# Altium schematic sheet TPS612997YBHR_3.6V_lowIQ_1.9A.SchDoc
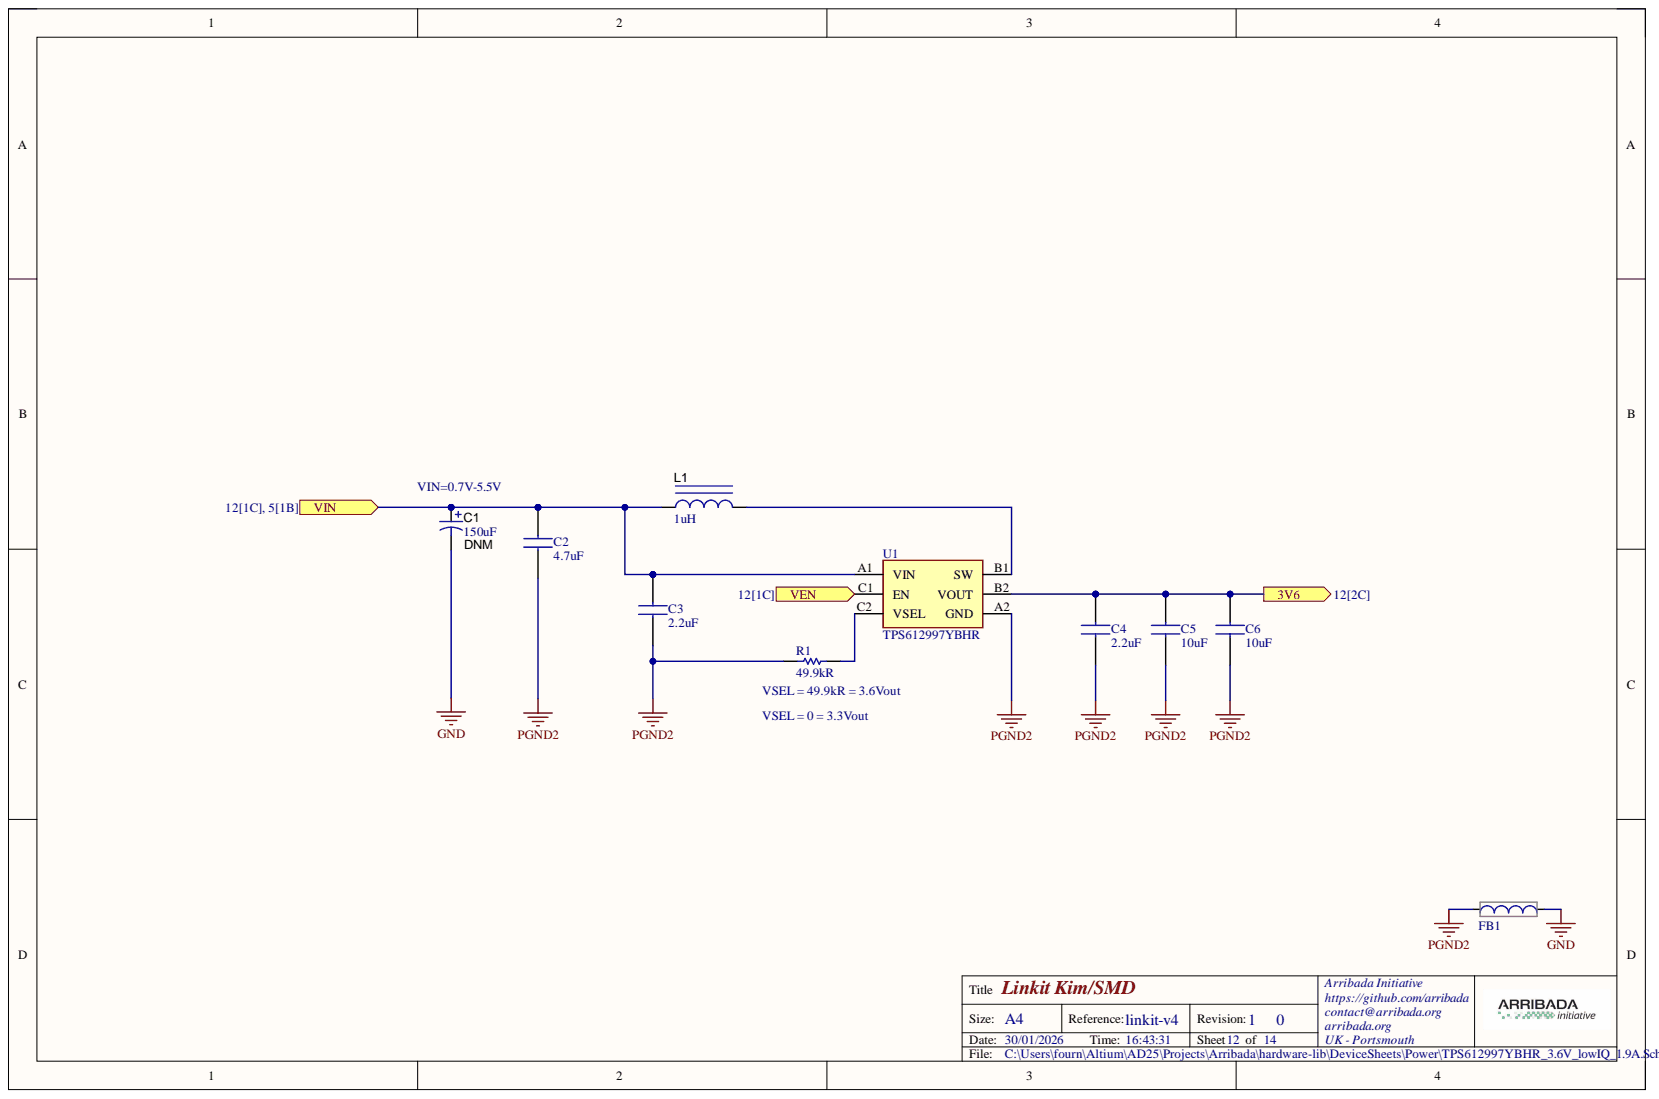
[diagram p0: TPS612997YBHR_3.6V_lowIQ_1.9A.SchDoc]

## Parts
C1  DNM  value 150uF  [7343-31]
C2  value 4.7uF  [CAPC1608X90N]  (hidden comment: GRM188Z71A475ME15D)
C3  value 2.2uF  [CAPC1005X70N]  (hidden comment: GRM155R61A225ME95D)
C4  value 2.2uF  [CAPC1005X70N]  (hidden comment: GRM155R61A225ME95D)
C5  value 10uF  [CAPC1005X55N]  (hidden comment: GRM155R61A106ME11D)
C6  value 10uF  [CAPC1005X55N]  (hidden comment: GRM155R61A106ME11D)
FB1  BLM18AG102SN1D  [BLM18SP221SN1B]  (value not drawn)
L1  value 1uH  [XEL4020]  (hidden comment: XEL4020-102MEB)
R1  value 49.9kR  [RESC1005X40N]  (hidden comment: CRCW040249K9FKED)
U1  TPS612997YBHR  [BGA6C40P2X3_84X123X40]

## Ports
3V6, VEN, VIN

## Nets
GND: C1.2 FB1.2
PGND2: C2.2 C3.1 C4.1 C5.1 C6.1 FB1.1 R1.2 U1.A2(GND)
3V6: C4.2 C5.2 C6.2 U1.B2(VOUT) ; port 3V6
VEN: U1.C1(EN) ; port VEN
VIN: C1.1 C2.1 C3.2 L1.1 U1.A1(VIN) ; port VIN
NetL5_2: L1.2 U1.B1(SW)
NetR50_1: R1.1 U1.C2(VSEL)

## Library data of the parts
C1 = T495D157K010ATE100: Tantalum Capacitors - Solid SMD 10V 150uF 2917 10% ESR=100mOhms; Manufacturer: Kemet; AEC Category: Q200; AEC Qualified: N; Cap_F: 150µF; ESR_ohm: 1d; IRMS_A: 1.225A; Polarized: Y; SizeArea_mm2: 31.39; SizeAreaWB_mm2: 58.59; SizeX_mm: 7.3; SizeY_mm: 4.3; SizeZ_mm: 3.1; TaMax_C: 125°C; TaMin_C: -55°C; U/M: EA; Value1: 0.1 ohm; AEC Grade: N/A; Cap_Case: 7343-31; Component Kind: Standard; ComponentLink1Description: Datasheet link; ComponentLink1URL: http://www.kemet.com/Lists/ProductCatalog/Attachments/261/KEM_T2009_T495.pdf; CompType: Capacitor; LatestRevisionDate: 2017-03-09; LatestRevisionNote: Updated supplier links; Library Name: stm32wl-dev.SchLib; MountType: SMT; PackageReference: 7343-31; PartNumber: T495D157K010ATE100; RoHS: Y; Series: T495; Supplier 1: Digi-Key; Supplier 2: Newark; Supplier 3: Mouser; Supplier Part Number 1: 399-8506-1-ND; Supplier Part Number 2: 40K0920; Supplier Part Number 3: 80-T495D157K010; Technology: TA; Tolerance_%: 10%; Value2: 10V; ValueDisplayed: 150uF; VDC_V: 10V; Publisher: Texas Instruments
C2 = GRM188Z71A475ME15D: Multilayer Ceramic Capacitors MLCC - SMD/SMT 4.7 uF 10 VDC 20% 0603 X7R; Manufacturer: Murata; Manufacturer_Name: Murata Electronics; Case/Package: 0603; Category: Ceramic Capacitors; Component Kind: Standard; Datasheet Link: http://componentsearchengine.com/Datasheets/1/GRM188Z71A475ME15D.pdf; Height: 0.9mm; Library Name: stm32wl-dev.SchLib; Manufacturer Part Number: GRM188Z71A475ME15D; Manufacturer_Part_Number: GRM188Z71A475ME15D; Mouser Part Number: 81-GRM188Z71A475ME5D; Mouser Price/Stock: https://www.mouser.co.uk/ProductDetail/Murata-Electronics/GRM188Z71A475ME15D?qs=Ey7%2FXF42M3f7ZZnF1u%2FoMQ%3D%3D; Pricing 1: 1=0,2, 10=0,139, 50=0,0928, 100=0,0789, 500=0,0557, 1000=0,04873 (USD); RoHS: Yes; Stock 1: 6936; Supplier 1: Digi-Key
C3 C4 = GRM155R61A225ME95D: Multilayer Ceramic Capacitors MLCC - SMD/SMT 2.2 uF 10 VDC 20% 0402 X5R; Manufacturer_Name: Murata Electronics; Arrow Part Number: GRM155R61A225ME95D; Arrow Price/Stock: https://www.arrow.com/en/products/grm155r61a225me95d/murata-manufacturing; Component Kind: Standard; Datasheet Link: http://www.murata.com/~/media/webrenewal/support/library/catalog/products/capacitor/mlcc/c02e.pdf; Height: 0.7mm; Library Name: stm32wl-dev.SchLib; Manufacturer_Part_Number: GRM155R61A225ME95D; Mouser Part Number: 81-GRM155R61A225ME5D; Mouser Price/Stock: https://www.mouser.co.uk/ProductDetail/Murata-Electronics/GRM155R61A225ME95D?qs=eeBpzGFlv%252B9Q12VVb4vywQ%3D%3D
C5 C6 = GRM155R61A106ME11D: Multilayer Ceramic Capacitors MLCC - SMD/SMT 10 uF 10 VDC 20% 0402 X5R; Manufacturer_Name: Murata Electronics; Arrow Part Number: GRM155R61A106ME11D; Arrow Price/Stock: https://www.arrow.com/en/products/grm155r61a106me11d/murata-manufacturing?region=nac; Component Kind: Standard; Datasheet Link: http://www.murata.com/~/media/webrenewal/support/library/catalog/products/capacitor/mlcc/c02e.pdf; Height: 0.55mm; Library Name: stm32wl-dev.SchLib; Manufacturer_Part_Number: GRM155R61A106ME11D; Mouser Part Number: 81-GRM155R61A106ME1D; Mouser Price/Stock: https://www.mouser.co.uk/ProductDetail/Murata-Electronics/GRM155R61A106ME11D?qs=xZ%2FP%252Ba9zWqZMJSi57Q8OyA%3D%3D
FB1 = BLM18AG102SN1D: Ferrite Bead - 1000 OHM 25%; Manufacturer_Name: Murata Electronics; Arrow Part Number: BLM18AG102SN1D; Arrow Price/Stock: https://www.arrow.com/en/products/blm18ag102sn1d/murata-manufacturing?utm_currency=USD&region=nac; Component Kind: Standard; Datasheet Link: http://www.murata.com/~/media/webrenewal/support/library/catalog/products/emc/emifil/c31e.ashx?la=en-gb; Height: 0.95mm; Library Name: stm32wl-dev.SchLib; Manufacturer_Part_Number: BLM18AG102SN1D; Mouser Part Number: 81-BLM11A102S; Mouser Price/Stock: https://www.mouser.co.uk/ProductDetail/Murata-Electronics/BLM18AG102SN1D?qs=b2kFoOhrN4V4XjRBmusv4w%3D%3D
L1 = XEL4020-102MEC: Power Inductors - SMD 1uH Shld 20% 9.6A 14.6mOhms AECQ2; Manufacturer: Coilcraft; AEC Category: Q200; AEC Grade: 1; AEC Qualified: Y; DCR_ohm: 0.0133; Imax_A: 9A; Ind_H: 1µH; Irms: 9.6A @ 40C rise; Isat: 9A @ 30% inductance drop; Material: Composite; SizeArea_mm2: 16; SizeAreaWB_mm2: 25; SizeX_mm: 4; SizeZ_mm: 2.1; Value1: 0.0133 ohm; Component Kind: Standard; ComponentLink1Description: Datasheet link; ComponentLink1URL: http://www.coilcraft.com/pdfs/xel4020.pdf; CompType: Inductor; Library Name: stm32wl-dev.SchLib; MountType: SMT; PartNumber: XEL4020-102MEB; RoHS: Y; SizeY_mm: 4; Technology: Shielded; Value2: 9A; ValueDisplayed: 1uH
R1 = CRCW040249K9FKED: Thick Film Resistors - SMD 1/16watt 49.9Kohms 1%; Manufacturer_Name: Vishay; Arrow Part Number: CRCW040249K9FKED; Arrow Price/Stock: https://www.arrow.com/en/products/crcw040249k9fked/vishay?region=nac; Component Kind: Standard; Datasheet Link: http://www.vishay.com/docs/20035/dcrcwe3.pdf; Height: 0.4mm; Library Name: stm32wl-dev.SchLib; Manufacturer_Part_Number: CRCW040249K9FKED; Mouser Part Number: 71-CRCW0402-49.9K-E3; Mouser Price/Stock: https://www.mouser.co.uk/ProductDetail/Vishay-Dale/CRCW040249K9FKED?qs=hAuyw6LW%252BLhwjtjsHx%2FGDQ%3D%3D
U1 = TPS612997YBHR: Switching Voltage Regulators 95-nA low IQ 5.5-V b oost converter with INLIMIT (1.9A); Manufacturer_Name: Texas Instruments; Arrow Part Number: TPS612997YBHR; Arrow Price/Stock: https://www.arrow.com/en/products/tps612997ybhr/texas-instruments?utm_currency=USD&region=nac; Component Kind: Standard; Datasheet Link: https://www.ti.com/lit/gpn/tps61299; Height: 0.4mm; Library Name: stm32wl-dev.SchLib; Manufacturer_Part_Number: TPS612997YBHR; Mouser Part Number: 595-TPS612997YBHR; Mouser Price/Stock: https://www.mouser.co.uk/ProductDetail/Texas-Instruments/TPS612997YBHR?qs=mELouGlnn3dLhnQxUdz5hw%3D%3D
